# Altium schematic sheet CAR_FAN_RELAY_4.SchDoc(CAR_FAN_RELAY_4)
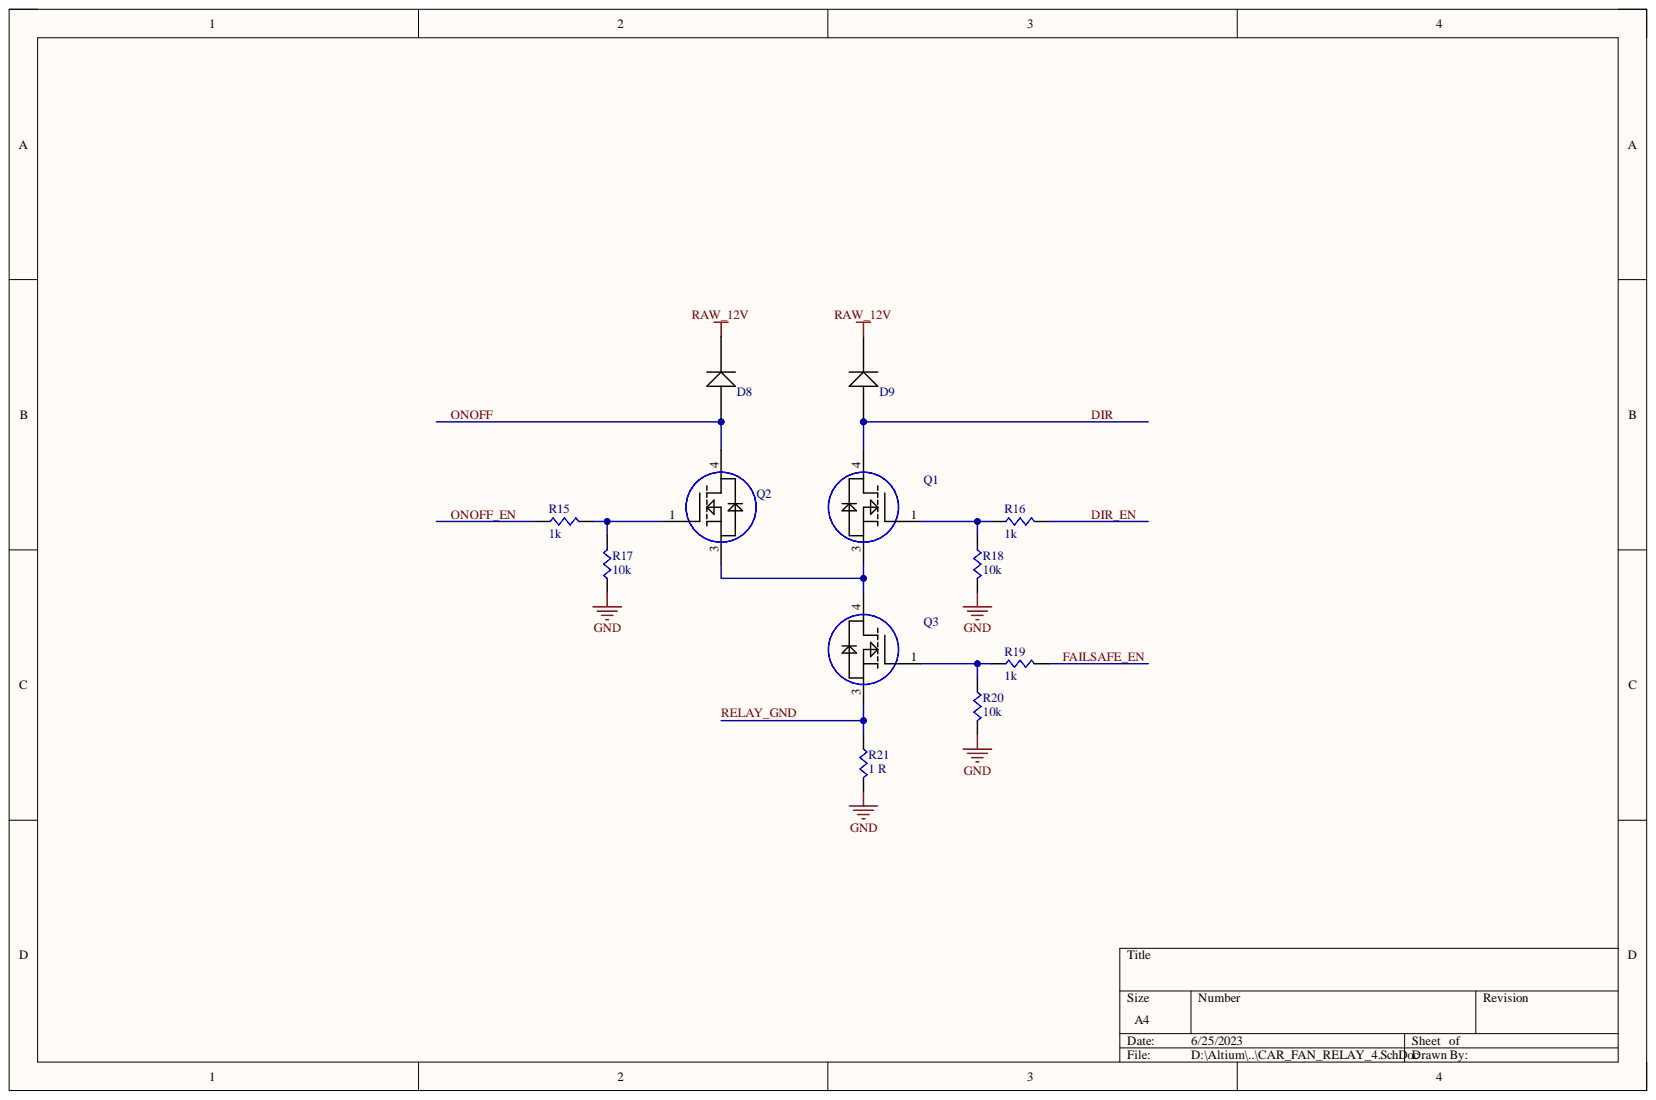
[diagram p0: CAR_FAN_RELAY_4.SchDoc]

## Parts
D8  SK510B-LTP  [SMB]  (value not drawn)
D9  SK510B-LTP  [SMB]  (value not drawn)
Q1  FDD8896  [TO-252]  (value not drawn)
Q2  FDD8896  [TO-252]  (value not drawn)
Q3  FDD8896  [TO-252]  (value not drawn)
R15  1k  [0603]
R16  1k  [0603]
R17  10k  [0603]
R18  10k  [0603]
R19  1k  [0603]
R20  10k  [0603]
R21  1 R  [0805]

## Nets
GND: R17.2 R18.2 R20.2 R21.2
RAW_12V: D8.K D9.K
DIR: D9.A Q1.4
DIR_EN: R16.2
FAILSAFE_EN: R19.2
ONOFF: D8.A Q2.4
ONOFF_EN: R15.2
RELAY_GND: Q3.3 R21.1
NetQ1_1: Q1.1 R16.1 R18.1
NetQ1_3: Q1.3 Q2.3 Q3.4
NetQ2_1: Q2.1 R15.1 R17.1
NetQ3_1: Q3.1 R19.1 R20.1

## Library data of the parts
D8 D9 = DIODE: 5A Shottky rectifier diode; Component Kind: Standard; Library Name: CAR_FAN_RELAY.SchLib
Q1-Q3 = N-MOSFET: Component Kind: Standard; Library Name: CAR_FAN_RELAY.SchLib
R15-R21 = RES: Resistor; Component Kind: Standard; Library Name: CAR_FAN_RELAY.SchLib
